# Altium schematic sheet BoardRev2.SchDoc
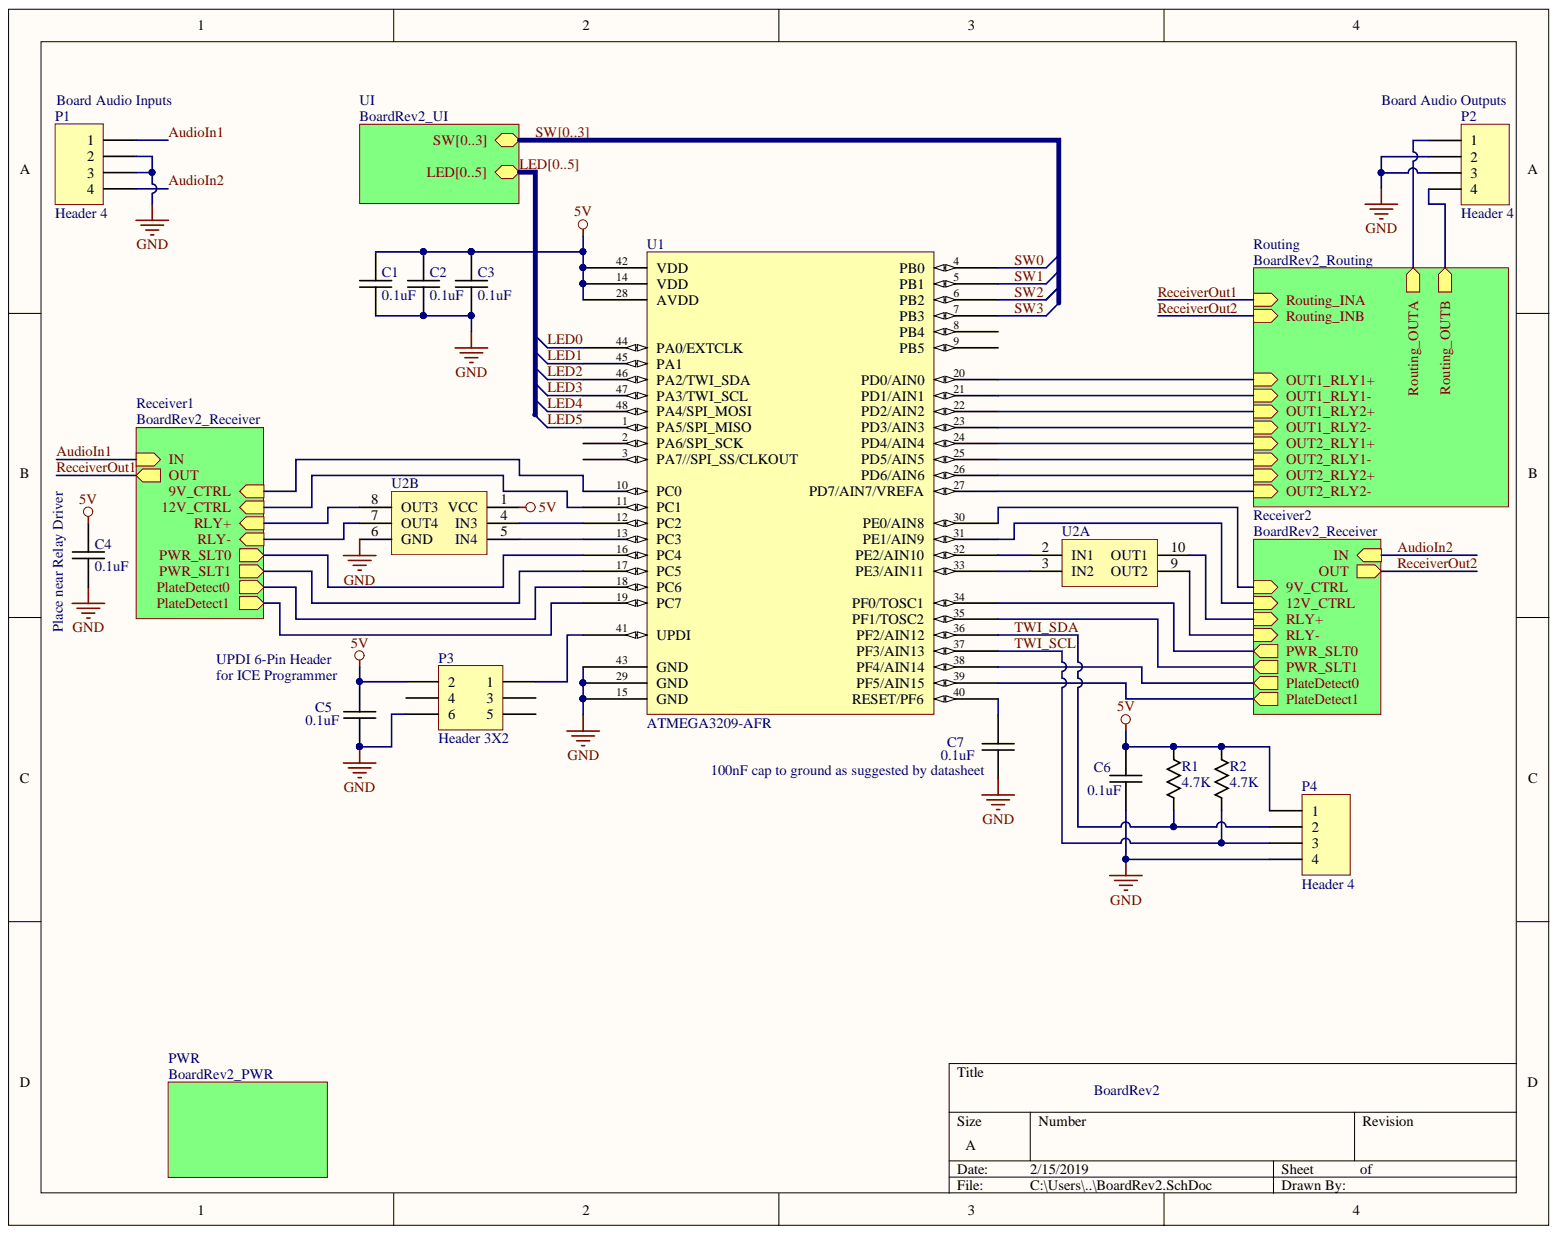
[diagram p0: BoardRev2.SchDoc]

## Parts
C1  0.1uF  [0805]
C2  0.1uF  [0805]
C3  0.1uF  [0805]
C4  0.1uF  [0805]
C5  0.1uF  [0805]
C6  0.1uF  [0805]
C7  0.1uF  [0805]
P1  Header 4  [HDR1X4]
P2  Header 4  [HDR1X4]
P3  Header 3X2  [HDR2X3]
P4  Header 4  [HDR1X4]
R1  4.7K  [0805]
R2  4.7K  [0805]
U1  ATMEGA3209-AFR  [TQFP48]
U2  LV8548MC  [SOIC10]  (value not drawn)  (parts A,B)

## Nets
5V: C1.1 C2.1 C3.1 C4.1 C5.2 C6.2 P3.2 P4.1 R1.2 R2.2 U1.14(VDD) U1.28(AVDD) U1.42(VDD) U2.1(VCC)
GND: C1.2 C2.2 C3.2 C4.2 C5.1 C6.1 C7.1 P1.2 P1.3 P2.2 P2.3 P3.6 P4.4 U1.15(GND) U1.29(GND) U1.43(GND) U2.6(GND)
AudioIn1: P1.1
AudioIn2: P1.4
LED0: U1.44(PA0/EXTCLK)  [also labelled LED]
LED1: U1.45(PA1)  [also labelled LED]
LED2: U1.46(PA2/TWI_SDA)  [also labelled LED]
LED3: U1.47(PA3/TWI_SCL)  [also labelled LED]
LED4: U1.48(PA4/SPI_MOSI)  [also labelled LED]
LED5: U1.1(PA5/SPI_MISO)  [also labelled LED]
SW0: U1.4(PB0)  [also labelled SW]
SW1: U1.5(PB1)  [also labelled SW]
SW2: U1.6(PB2)  [also labelled SW]
SW3: U1.7(PB3)  [also labelled SW]
TWI_SCL: P4.3 R2.1 U1.37(PF3/AIN13)
TWI_SDA: P4.2 R1.1 U1.36(PF2/AIN12)
NetC7_2: C7.2 U1.40(RESET/PF6)
NetP2_1: P2.1
NetP2_4: P2.4
NetP3_1: P3.1 U1.41(UPDI)
NetP3_3: P3.3
NetP3_4: P3.4
NetP3_5: P3.5
NetU1_2: U1.2(PA6/SPI_SCK)
NetU1_3: U1.3(PA7//SPI_SS/CLKOUT)
NetU1_8: U1.8(PB4)
NetU1_9: U1.9(PB5)
NetU1_10: U1.10(PC0)
NetU1_11: U1.11(PC1)
NetU1_12: U1.12(PC2) U2.4(IN3)
NetU1_13: U1.13(PC3) U2.5(IN4)
NetU1_16: U1.16(PC4)
NetU1_17: U1.17(PC5)
NetU1_18: U1.18(PC6)
NetU1_19: U1.19(PC7)
NetU1_20: U1.20(PD0/AIN0)
NetU1_21: U1.21(PD1/AIN1)
NetU1_22: U1.22(PD2/AIN2)
NetU1_23: U1.23(PD3/AIN3)
NetU1_24: U1.24(PD4/AIN4)
NetU1_25: U1.25(PD5/AIN5)
NetU1_26: U1.26(PD6/AIN6)
NetU1_27: U1.27(PD7/AIN7/VREFA)
NetU1_30: U1.30(PE0/AIN8)
NetU1_31: U1.31(PE1/AIN9)
NetU1_32: U1.32(PE2/AIN10) U2.2(IN1)
NetU1_33: U1.33(PE3/AIN11) U2.3(IN2)
NetU1_34: U1.34(PF0/TOSC1)
NetU1_35: U1.35(PF1/TOSC2)
NetU1_38: U1.38(PF4/AIN14)
NetU1_39: U1.39(PF5/AIN15)
NetU2_7: U2.7(OUT4)
NetU2_8: U2.8(OUT3)
NetU2_9: U2.9(OUT2)
NetU2_10: U2.10(OUT1)
ReceiverOut1:
ReceiverOut2:

## Library data of the parts
C1-C7 = Cap: Component Kind: Standard; Library Name: NickPhamParts3.IntLib
P1 P2 P4 = Header 4: Header, 4-Pin; Component Kind: Standard; LatestRevisionDate: 17-Jul-2002; LatestRevisionNote: Re-released for DXP Platform.; Library Name: Miscellaneous Connectors.IntLib; Signal Integrity: Connector; Publisher: Altium Limited
P3 = Header 3X2: Header, 3-Pin, Dual row; Component Kind: Standard; LatestRevisionDate: 17-Jul-2002; LatestRevisionNote: Re-released for DXP Platform.; Library Name: Miscellaneous Connectors.IntLib; Signal Integrity: Connector; Publisher: Altium Limited
R1 R2 = Res: Component Kind: Standard; Library Name: NickPhamParts3.IntLib
U1 = ATMEGA3209-AFR: IC MCU 8BIT 32KB FLASH 48TQFP; Component Kind: Standard; Library Name: NickPhamParts3.IntLib
U2 = LV8548MC: Motor Driver Dual H-Bridge, Forward/Reverse, Low Saturation Voltage; Component Kind: Standard; Library Name: NickPhamParts3.IntLib
